# Altium schematic sheet Input Buffer.SchDoc
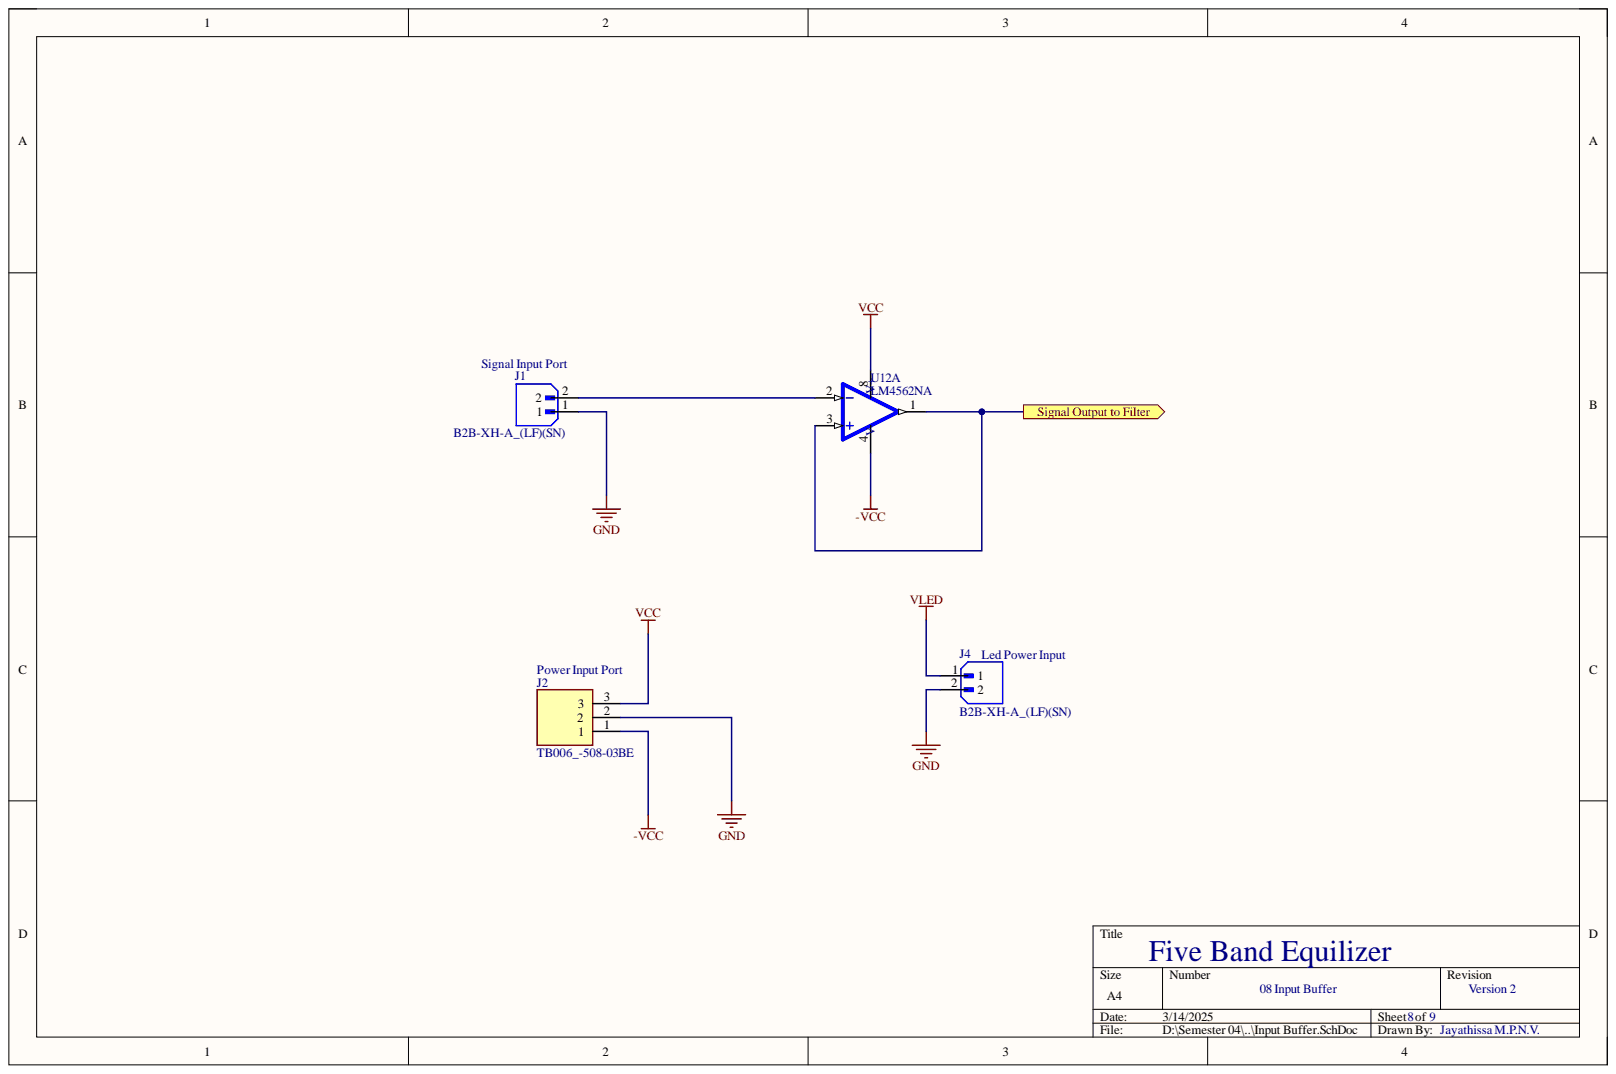
[diagram p0: Input Buffer.SchDoc]

## Parts
J1  B2B-XH-A_(LF)(SN)  [JST_B2B-XH-A_(LF)(SN)]
J2  TB006_-508-03BE  [CUI_TB006 -508-03BE]
J4  B2B-XH-A_(LF)(SN)  [JST_B2B-XH-A_(LF)(SN)]
U12  LM4562NA  [DIP794W45P254L959H508Q8]  (part A)

## Ports
Signal Output to Filter

## Nets
-VCC: J2.1 U12.4
GND: J1.1 J2.2 J4.2
VCC: J2.3 U12.8
VLED: J4.1
Signal Output to Filter: U12.1 U12.3(V-) ; port Signal Output to Filter
NetJ1_2: J1.2 U12.2

## Library data of the parts
J1 J4 = CMP-002-00072-1: Connector Header Through Hole 2 position 0.098 (2.50mm); MF: JST Sales; MP: B2B-XH-A (LF)(SN); Case/Package: None; Check_prices: https://www.snapeda.com/parts/B2B-XH-A%20(LF)(SN)/JST+Sales+America+Inc./view-part/?ref=eda; Component Kind: Standard; Component Type: Standard; Design Item ID: B2B-XH-A_(LF)(SN); Pins: 2; SnapEDA_Link: https://www.snapeda.com/parts/B2B-XH-A%20(LF)(SN)/JST+Sales+America+Inc./view-part/?ref=snap; Source: B2B-XH-A_(LF)(SN).SchLib; Library Name: Nirwan Jayathissa
J2 = CMP-002-00071-1: 2~24 Poles, Screw Type, Horizontal, 5.08 Pitch, 26~14 (AWG), Terminal Block Connector; MF: CUI Devices; MP: TB006 -508-03BE; Availability: In Stock; Case/Package: None; Check_prices: https://www.snapeda.com/parts/TB006%20-508-03BE/CUI+Devices/view-part/?ref=eda; Component Kind: Standard; Component Type: Standard; CUI_purchase_URL: https://www.cuidevices.com/product/interconnect/connectors/terminal-blocks/tb006-508-series?utm_source=snapeda.com&utm_medium=referral&utm_campaign=snapedaBOM; Design Item ID: TB006_-508-03BE; Pins: 3; Price: None; Purchase-URL: https://pricing.snapeda.com/search/part/TB006 -508-03BE/?ref=eda; SnapEDA_Link: https://www.snapeda.com/parts/TB006%20-508-03BE/CUI+Devices/view-part/?ref=snap; Source: TB006_-508-03BE.SchLib; Library Name: Nirwan Jayathissa
U12 = CMP-014-00002-1: LM4562 2 - Dual Channels per IC Audio Amplifier Evaluation Board; MF: Texas Instruments; Availability: In Stock; Case/Package: DIP-8 Texas Instruments; Check_prices: https://www.snapeda.com/parts/LM4562NA/Texas+Instruments/view-part/?ref=eda; Component Kind: Standard; Component Type: Standard; Design Item ID: LM4562NA; MP: LM4562NA; Pins: 8; Price: None; Purchase-URL: https://pricing.snapeda.com/search/part/LM4562NA/?ref=eda; SnapEDA_Link: https://www.snapeda.com/parts/LM4562NA/Texas+Instruments/view-part/?ref=snap; Source: LM4562NA.SchLib; Library Name: Nirwan Jayathissa
